# Altium schematic sheet CELLULAR - nRF9160.SchDoc
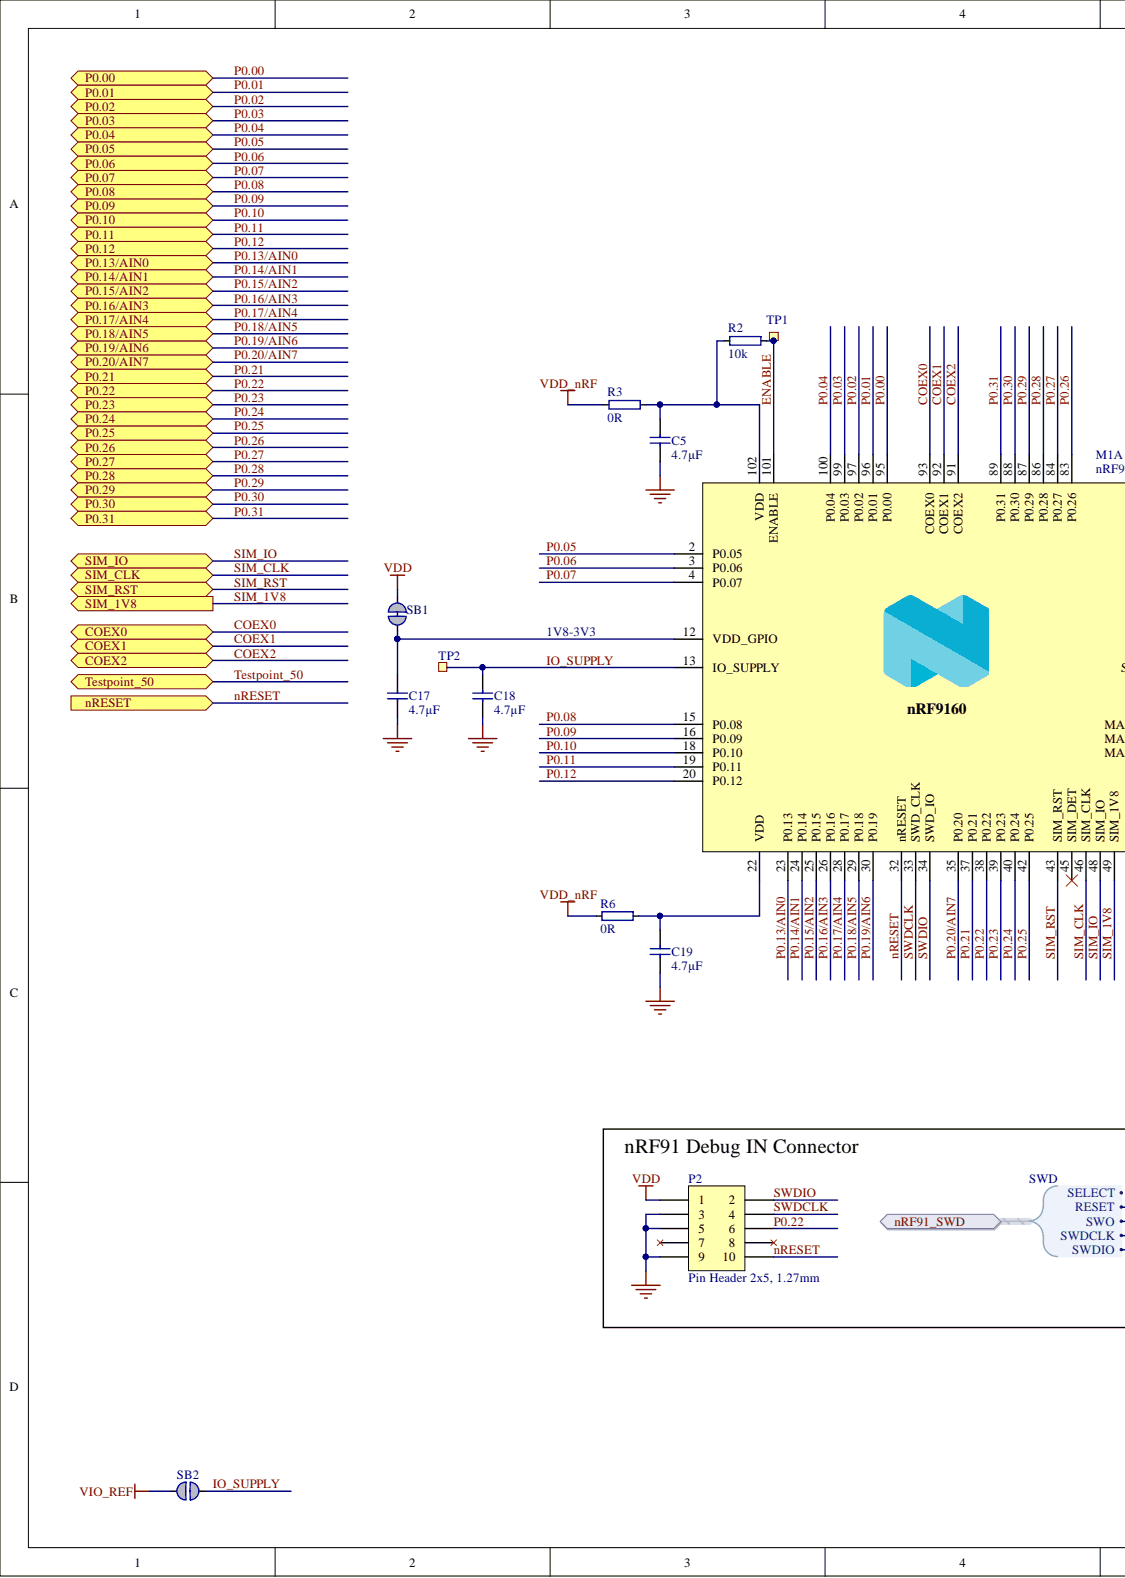
[diagram p0: CELLULAR - nRF9160.SchDoc, left]
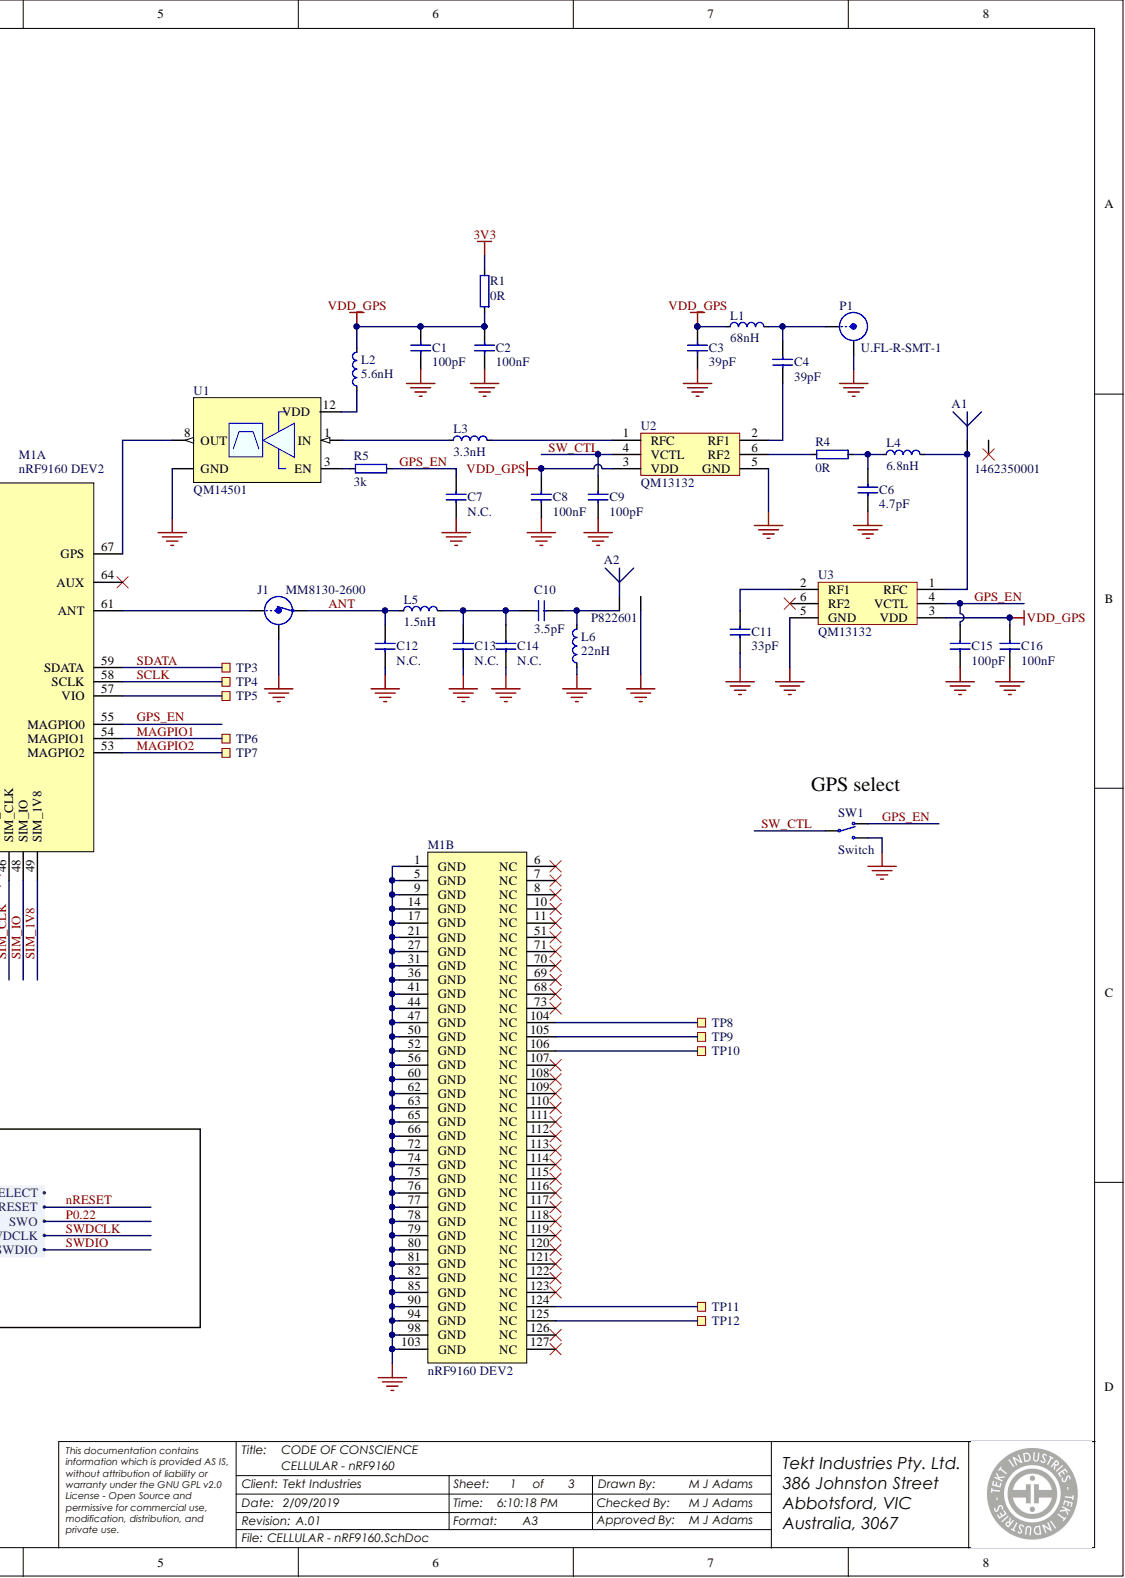
[diagram p1: CELLULAR - nRF9160.SchDoc, right]

## Parts
A1  1462350001  [1462350001]
A2  P822601  [P822601]
C1  100pF  [CAPC0603X03L_C]
C2  100nF  [CAPC0603X03L_C]
C3  39pF  [CAPC0603X03L_C]
C4  39pF  [CAPC0603X03L_C]
C5  4.7µF  [CAPC1005X04L]
C6  4.7pF  [CAPC0603X03L_C]
C7  N.C.  [CAPC0603X03L_C]
C8  100nF  [CAPC0603X03L_C]
C9  100pF  [CAPC0603X03L_C]
C10  3.5pF  [CAPC0603X03L_C]
C11  33pF  [CAPC0603X03L_C]
C12  N.C.  [CAPC0603X03L_C]
C13  N.C.  [CAPC0603X03L_C]
C14  N.C.  [CAPC0603X03L_C]
C15  100pF  [CAPC0603X03L_C]
C16  100nF  [CAPC0603X03L_C]
C17  4.7µF  [CAPC1005X04L]
C18  4.7µF  [CAPC1005X04L]
C19  4.7µF  [CAPC1005X04L]
J1  MM8130-2600  [COAXIAL-SWF]
L1  68nH  [INDC1005X04L]
L2  5.6nH  [INDC0603X03L_C]
L3  3.3nH  [INDC0603X03L_C]
L4  6.8nH  [INDC0603X03L_C]
L5  1.5nH  [INDC0603X03L_C]
L6  22nH  [INDC0603X03L_C]
M1  nRF9160 DEV2  [nRF9160_DEV2_MODULE]  (parts A,B)
P1  U.FL-R-SMT-1  [COAXIAL RF U.FL-R-SMT-1]
P2  Pin Header 2x5, 1.27mm  [HDR_2x5-SMD-1.27mm-K]
R1  0R  [RESC1005X04L]
R2  10k  [RESC0603X03L_C]
R3  0R  [RESC0603X03L_C]
R4  0R  [RESC0603X03L_C]
R5  3k  [RESC0603X03L_C]
R6  0R  [RESC0603X03L_C]
SB1  Solderbridge  [Solderbridge_Shorted]  (value not drawn)
SB2  Solderbridge  [Solderbridge]  (value not drawn)  (no BOM)
SW1  Switch  [CJS-120xA]
TP1  Ø1.5mm,SMD  [TP_SMD_Ø1.5mm]  (value not drawn)  (no BOM)
TP2  Ø1.0mm,SMD  [TP_SMD_Ø1.0mm]  (value not drawn)  (no BOM)
TP3  Ø1.0mm,SMD  [TP_SMD_Ø1.0mm]  (value not drawn)  (no BOM)
TP4  Ø1.0mm,SMD  [TP_SMD_Ø1.0mm]  (value not drawn)  (no BOM)
TP5  Ø1.0mm,SMD  [TP_SMD_Ø1.0mm]  (value not drawn)  (no BOM)
TP6  Ø1.0mm,SMD  [TP_SMD_Ø1.0mm]  (value not drawn)  (no BOM)
TP7  Ø1.0mm,SMD  [TP_SMD_Ø1.0mm]  (value not drawn)  (no BOM)
TP8  Ø1.0mm,SMD  [TP_SMD_Ø1.0mm]  (value not drawn)  (no BOM)
TP9  Ø1.0mm,SMD  [TP_SMD_Ø1.0mm]  (value not drawn)  (no BOM)
TP10  Ø1.0mm,SMD  [TP_SMD_Ø1.0mm]  (value not drawn)  (no BOM)
TP11  Ø1.0mm,SMD  [TP_SMD_Ø1.0mm]  (value not drawn)  (no BOM)
TP12  Ø1.0mm,SMD  [TP_SMD_Ø1.0mm]  (value not drawn)  (no BOM)
U1  QM14501  [QM14501]
U2  QM13132  [QM13132]
U3  QM13132  [QM13132]

## Ports
COEX0, COEX1, COEX2, nRESET, nRF91_SWD, nRF91_SWD.RESET, nRF91_SWD.SELECT, nRF91_SWD.SWDCLK, nRF91_SWD.SWDIO, nRF91_SWD.SWO, P0.00, P0.01, P0.02, P0.03, P0.04, P0.05, P0.06, P0.07, P0.08, P0.09, P0.10, P0.11, P0.12, P0.13/AIN0, P0.14/AIN1, P0.15/AIN2, P0.16/AIN3, P0.17/AIN4, P0.18/AIN5, P0.19/AIN6, P0.20/AIN7, P0.21, P0.22, P0.23, P0.24, P0.25, P0.26, P0.27, P0.28, P0.29, P0.30, P0.31, SIM_1V8, SIM_CLK, SIM_IO, SIM_RST, Testpoint_50

## Nets
3V3: R1.1
GND: A2.2 C1.1 C2.1 C3.2 C5.2 C6.2 C7.1 C8.2 C9.2 C11.2 C12.1 C13.1 C14.1 C15.2 C16.2 C17.2 C18.2 C19.1 J1.2 L6.1 M1.1(GND) M1.5(GND) M1.9(GND) M1.14(GND) M1.17(GND) M1.21(GND) M1.27(GND) M1.31(GND) M1.36(GND) M1.41(GND) M1.44(GND) M1.47(GND) M1.50(GND) M1.52(GND) M1.56(GND) M1.60(GND) M1.62(GND) M1.63(GND) M1.65(GND) M1.66(GND) M1.72(GND) M1.74(GND) M1.75(GND) M1.76(GND) M1.77(GND) M1.78(GND) M1.79(GND) M1.80(GND) M1.81(GND) M1.82(GND) M1.85(GND) M1.90(GND) M1.94(GND) M1.98(GND) M1.103(GND) P1.2 P2.3 P2.5 P2.9 SW1.3 U1.2(GND) U1.4 U1.5 U1.6 U1.7 U1.9 U1.10 U1.11 U2.5(GND) U3.5(GND)
VDD: P2.1 SB1.1
VDD_GPS: C1.2 C2.2 C3.1 C8.1 C16.1 L1.1 L2.2 R1.2 U2.3(VDD) U3.3(VDD)
VDD_nRF: R3.1 R6.1
VIO_REF: SB2.2
ANT: C12.2 J1.3 L5.1
COEX0: M1.93(COEX0) ; port COEX0
COEX1: M1.92(COEX1) ; port COEX1
COEX2: M1.91(COEX2) ; port COEX2
ENABLE: M1.101(ENABLE) R2.2 TP1.1
GPS_EN: C7.2 C15.1 M1.55(MAGPIO0) R5.2 SW1.1 U3.4(VCTL)
IO_SUPPLY: C18.1 M1.13(IO_SUPPLY) SB2.1 TP2.1
MAGPIO1: M1.54(MAGPIO1) TP6.1
MAGPIO2: M1.53(MAGPIO2) TP7.1
nRESET: M1.32(nRESET) P2.10 ; port nRESET, port nRF91_SWD
P0.00: M1.95(P0.00) ; port P0.00
P0.01: M1.96(P0.01) ; port P0.01
P0.02: M1.97(P0.02) ; port P0.02
P0.03: M1.99(P0.03) ; port P0.03
P0.04: M1.100(P0.04) ; port P0.04
P0.05: M1.2(P0.05) ; port P0.05
P0.06: M1.3(P0.06) ; port P0.06
P0.07: M1.4(P0.07) ; port P0.07
P0.08: M1.15(P0.08) ; port P0.08
P0.09: M1.16(P0.09) ; port P0.09
P0.10: M1.18(P0.10) ; port P0.10
P0.11: M1.19(P0.11) ; port P0.11
P0.12: M1.20(P0.12) ; port P0.12
P0.13/AIN0: M1.23(P0.13) ; port P0.13/AIN0
P0.14/AIN1: M1.24(P0.14) ; port P0.14/AIN1
P0.15/AIN2: M1.25(P0.15) ; port P0.15/AIN2
P0.16/AIN3: M1.26(P0.16) ; port P0.16/AIN3
P0.17/AIN4: M1.28(P0.17) ; port P0.17/AIN4
P0.18/AIN5: M1.29(P0.18) ; port P0.18/AIN5
P0.19/AIN6: M1.30(P0.19) ; port P0.19/AIN6
P0.20/AIN7: M1.35(P0.20) ; port P0.20/AIN7
P0.21: M1.37(P0.21) ; port P0.21
P0.22: M1.38(P0.22) P2.6 ; port P0.22, port nRF91_SWD
P0.23: M1.39(P0.23) ; port P0.23
P0.24: M1.40(P0.24) ; port P0.24
P0.25: M1.42(P0.25) ; port P0.25
P0.26: M1.83(P0.26) ; port P0.26
P0.27: M1.84(P0.27) ; port P0.27
P0.28: M1.86(P0.28) ; port P0.28
P0.29: M1.87(P0.29) ; port P0.29
P0.30: M1.88(P0.30) ; port P0.30
P0.31: M1.89(P0.31) ; port P0.31
SCLK: M1.58(SCLK) TP4.1
SDATA: M1.59(SDATA) TP3.1
SIM_1V8: M1.49(SIM_1V8) ; port SIM_1V8
SIM_CLK: M1.46(SIM_CLK) ; port SIM_CLK
SIM_IO: M1.48(SIM_IO) ; port SIM_IO
SIM_RST: M1.43(SIM_RST) ; port SIM_RST
SW_CTL: C9.1 SW1.2 U2.4(VCTL)
SWDCLK: M1.33(SWD_CLK) P2.4 ; port nRF91_SWD
SWDIO: M1.34(SWD_IO) P2.2 ; port nRF91_SWD
NetA1_1: A1.1 L4.2 U3.1(RFC)
NetA2_1: A2.1 C10.2 L6.2
NetC4_1: C4.1 U2.2(RF1)
NetC4_2: C4.2 L1.2 P1.1
NetC5_1: C5.1 M1.102(VDD) R2.1 R3.2
NetC6_1: C6.1 L4.1 R4.2
NetC10_1: C10.1 C13.2 C14.2 L5.2
NetC11_1: C11.1 U3.2(RF1)
NetC17_1: C17.1 M1.12(VDD_GPIO) SB1.2
NetC19_2: C19.2 M1.22(VDD) R6.2
NetJ1_1: J1.1 M1.61(ANT)
NetL2_1: L2.1 U1.12(VDD)
NetL3_1: L3.1 U1.1(IN)
NetL3_2: L3.2 U2.1(RFC)
NetM1_57: M1.57(VIO) TP5.1
NetM1_67: M1.67(GPS) U1.8(OUT)
NetM1_104: M1.104(NC) TP8.1
NetM1_105: M1.105(NC) TP9.1
NetM1_106: M1.106(NC) TP10.1
NetM1_124: M1.124(NC) TP11.1
NetM1_125: M1.125(NC) TP12.1
NetR4_1: R4.1 U2.6(RF2)
NetR5_1: R5.1 U1.3(EN)
N00012: ; port nRF91_SWD
Testpoint_50: ; port Testpoint_50

Not connected: A1 2; M1 6(NC) 7(NC) 8(NC) 10(NC) 11(NC) 45(SIM_DET) 51(NC) 64(AUX) 68(NC) 69(NC) 70(NC) 71(NC) 73(NC) 107(NC) 108(NC) 109(NC) 110(NC) 111(NC) 112(NC) 113(NC) 114(NC) 115(NC) 116(NC) 117(NC) 118(NC) 119(NC) 120(NC) 121(NC) 122(NC) 123(NC) 126(NC) 127(NC); P2 7 8; U3 6(RF2)

## Library data of the parts
A1 = 1462350001_GPS: ANTENNA MOLDED 1.575GHZ GPS; Manufacturer: Molex; Artikkelnr Noca: N.A.; Assembly: Mounted; Database Table Name: Antennas$; Component Kind: Standard; ComponentLink1Description: Datasheet; ComponentLink2Description: Supplier link; Footprint Doc: 1462350001; HelpURL: https://www.molex.com/pdm_docs/sd/1462350001_sd.pdf; LatestRevisionDate: 2018-04-30; Library Name: Nordic Passive DB.DbLib; Manufacturer Part No.: 1462350001; Part Number: 1462350001_GPS; Part number IPS: N.A.; Pin Count: 3; Published: 2018-04-30; Supplier: Digi-Key; Supplier 2: 538-146235-0001; Supplier Part No.: WM15009CT-ND; Value: 1462350001; Publisher: Nordic Semiconductor
A2 = P822601: ANTENNA PRESTTA OCTABAND LTE; Manufacturer: Ethertronics; Artikkelnr Noca: N.A.; Database Table Name: Antennas$; Component Kind: Standard; ComponentLink1Description: Datasheet; ComponentLink2Description: Supplier link; Footprint Doc: P822601; HelpURL: http://www.ethertronics.com/wp-content/uploads/2016/11/Prestta-P822601-P822602-Standard-Cellular-Octa-Band-20160904.pdf; LatestRevisionDate: 2017-07-28; Library Name: Nordic Passive DB.DbLib; Manufacturer Part No.: P822601; Part Number: P822601; Part number IPS: N.A.; Pin Count: 2; Published: 2017-07-28; Supplier: Digi-Key; Supplier 2: Digikey; Supplier Part No.: 939-1057-1-ND; Supplier Part Number 2: 939-1057-1-ND; Value: P822601; Publisher: Nordic Semiconductor
C1 C9 C15 = C0201-100p: Capacitor, NP0, ±2%; Manufacturer: Murata Electronics North America; Manufacturer Part No.: GRM0335C1E101JA01D; Part number IPS: 00000007853; Artikkelnr Noca: N.A.; Database Table Name: Capacitors$; Note: 25V; Component Kind: Standard; ComponentLink1Description: Datasheet; ComponentLink2Description: Supplier link; Footprint Doc: 0201; LatestRevisionDate: 2017-11-09; Library Name: Nordic Passive DB.DbLib; Part Number: C0201-100p; Pin Count: 2; Published: 2014-11-13; Supplier: Digi-Key; Supplier Part No.: 490-3160-1-ND; Value: 100pF; Publisher: Nordic Semiconductor
C2 C8 C16 = C0201-100n: Capacitor, X5R, ±10%; Manufacturer: Murata Electronics North America; Manufacturer Part No.: GRM033R61C104KE84D; Part number IPS: 00000001248; Artikkelnr Noca: N.A.; Database Table Name: Capacitors$; Note: 16V; Component Kind: Standard; ComponentLink1Description: Datasheet; ComponentLink2Description: Supplier link; Footprint Doc: 0201; LatestRevisionDate: 2017-11-09; Library Name: Nordic Passive DB.DbLib; Part Number: C0201-100n; Pin Count: 2; Published: 2014-11-13; Supplier: Digi-Key; Supplier Part No.: 490-9985-1-ND; Value: 100nF; Publisher: Nordic Semiconductor
C3 C4 = C0201-39p: Chip Cap 0201; Manufacturer: Murata Electronics North America; Manufacturer Part No.: GRM0335C1H390JA01D; Artikkelnr Noca: N.A.; Database Table Name: Capacitors$; Note: Chip Cap 1%; Component Kind: Standard; ComponentLink1Description: Datasheet; ComponentLink2Description: Supplier link; Footprint Doc: 0201; LatestRevisionDate: 2014-11-13; Library Name: Nordic Passive DB.DbLib; Part Number: C0201-39p; Part number IPS: N.A.; Pin Count: 2; Published: 2014-11-13; Supplier: Digi-Key; Supplier Part No.: 490-11326-1-ND; Value: 39pF; Publisher: Nordic Semiconductor
C5 C17-C19 = C0402-4µ7: Capacitor, X5R, ±20%; Manufacturer: Murata Electronics North America; Manufacturer Part No.: ZRB15XR61A475KE01D; Part number IPS: 00000001251; Artikkelnr Noca: 20039482; Database Table Name: Capacitors$; Note: 10V; Component Kind: Standard; ComponentLink1Description: Datasheet; ComponentLink2Description: Supplier link; Footprint Doc: 0402; LatestRevisionDate: 2017-11-09; Library Name: Nordic Passive DB.DbLib; Part Number: C0402-4µ7; Pin Count: 2; Published: 2009-05-08; Supplier: Digi-Key; Supplier Part No.: 490-13252-1-ND; Value: 4.7µF; Publisher: Nordic Semiconductor
C6 = C0201-4.7p: Chip Cap 0201; Manufacturer: Murata Electronics North America; Manufacturer Part No.: GRM0335C1H4R7CA01D; Artikkelnr Noca: N.A.; Database Table Name: Capacitors$; Note: Chip Cap 1%; Component Kind: Standard; ComponentLink1Description: Datasheet; ComponentLink2Description: Supplier link; Footprint Doc: 0201; LatestRevisionDate: 2014-11-13; Library Name: Nordic Passive DB.DbLib; Part Number: C0201-4.7p; Part number IPS: N.A.; Pin Count: 2; Published: 2014-11-13; Supplier: Digi-Key; Supplier Part No.: 490-6130-1-ND; Value: 4.7pF; Publisher: Nordic Semiconductor
C7 C12-C14 = C0201-N.C.: Not mounted; Artikkelnr Noca: N.A.; Assembly: Not mounted; Database Table Name: Capacitors$; Note: Chip Cap; Component Kind: Standard; ComponentLink1Description: Datasheet; ComponentLink2Description: Supplier link; Footprint Doc: 0201; LatestRevisionDate: 2014-11-13; Library Name: Nordic Passive DB.DbLib; Part Number: C0201-N.C.; Part number IPS: N.A.; Pin Count: 2; Published: 2014-11-13; Value: N.C.; Publisher: Nordic Semiconductor
C10 = C0201-3.3p: Chip Cap 0201; Manufacturer: Taiyo Yuden; Manufacturer Part No.: UMK063CG3R5CTHF; Artikkelnr Noca: N.A.; Database Table Name: Capacitors$; Note: Chip Cap 1%; Component Kind: Standard; ComponentLink1Description: Datasheet; ComponentLink2Description: Supplier link; Footprint Doc: 0201; LatestRevisionDate: 2014-11-13; Library Name: Nordic Passive DB.DbLib; Part Number: C0201-3.3p; Part number IPS: N.A.; Pin Count: 2; Published: 2014-11-13; Supplier: Digi-Key; Supplier Part No.: 587-5326-1-ND; Value: 3.5pF; Publisher: Nordic Semiconductor
C11 = C0201-33p: Chip Cap 0201; Manufacturer: Murata Electronics North America; Manufacturer Part No.: GRM0335C1H330JA01D; Artikkelnr Noca: N.A.; Database Table Name: Capacitors$; Note: Chip Cap 1%; Component Kind: Standard; ComponentLink1Description: Datasheet; ComponentLink2Description: Supplier link; Footprint Doc: 0201; LatestRevisionDate: 2014-11-13; Library Name: Nordic Passive DB.DbLib; Part Number: C0201-33p; Part number IPS: N.A.; Pin Count: 2; Published: 2014-11-13; Supplier: Digi-Key; Supplier Part No.: 490-6126-1-ND; Value: 33pF; Publisher: Nordic Semiconductor
J1 = MM8130-2600: Coaxial Connector with Switch; Manufacturer: Murata; Part number IPS: N.A.; Artikkelnr Noca: 55029011; Database Table Name: Connectors$; Component Kind: Standard; ComponentLink1Description: Datasheet; ComponentLink2Description: Supplier link; Footprint Doc: COAXIAL-SWF; HelpURL: http://www.murata.com/products/catalog/pdf/o33e.pdf; LatestRevisionDate: 2014-04-24; Library Name: Nordic Passive DB.DbLib; Manufacturer Part No.: MM8130-2600; Part Number: MM8130-2600; Pin Count: 3; Published: 2014-04-24; Supplier: Digi-Key; Supplier 2: Mouser; Supplier Part No.: 490-4981-1-ND; Supplier Part Number 2: 81-MM8130-2600RA2; Value: MM8130-2600; Publisher: Nordic Semiconductor
L1 = L0402-68n: Chip Inductor; Manufacturer: Murata Electronics North America; Manufacturer Part No.: LQG15HS68NJ02D; Artikkelnr Noca: N.A.; Database Table Name: Inductors$; Component Kind: Standard; ComponentLink1Description: Datasheet; ComponentLink2Description: Supplier link; Footprint Doc: 0402; LatestRevisionDate: 2014-06-12; Library Name: Nordic Passive DB.DbLib; Part Number: L0402-68n; Part number IPS: N.A.; Pin Count: 2; Published: 2014-06-12; Supplier: Digi-Key; Supplier Part No.: 490-2633-1-ND; Value: 68nH; Publisher: Nordic Semiconductor
L2 = L0201-5n6: Chip Inductor; Manufacturer: Murata Electronics North America; Manufacturer Part No.: LQP03TN5N6H02D; Artikkelnr Noca: N.A.; Database Table Name: Inductors$; Note: High frequency chip inductor ±5%; Component Kind: Standard; ComponentLink1Description: Datasheet; ComponentLink2Description: Supplier link; Footprint Doc: 0201; LatestRevisionDate: 2013-04-10; Library Name: Nordic Passive DB.DbLib; Part Number: L0201-5n6; Part number IPS: N.A.; Pin Count: 2; Published: 2013-04-10; Supplier: Digi-Key; Supplier Part No.: 490-6734-1-ND; Value: 5.6nH; Publisher: Nordic Semiconductor
L3 = L0201-3n3: Chip Inductor; Manufacturer: Murata Electronics North America; Manufacturer Part No.: LQP03TN3N3B02D; Artikkelnr Noca: N.A.; Database Table Name: Inductors$; Note: High frequency chip inductor ±5%; Component Kind: Standard; ComponentLink1Description: Datasheet; ComponentLink2Description: Supplier link; Footprint Doc: 0201; LatestRevisionDate: 2013-04-10; Library Name: Nordic Passive DB.DbLib; Part Number: L0201-3n3; Part number IPS: N.A.; Pin Count: 2; Published: 2013-04-10; Supplier: Digi-Key; Supplier Part No.: 490-6728-1-ND; Value: 3.3nH; Publisher: Nordic Semiconductor
L4 = L0201-6n8: Chip Inductor; Manufacturer: Murata Electronics North America; Manufacturer Part No.: LQP03TN6N8J02D; Artikkelnr Noca: N.A.; Database Table Name: Inductors$; Note: High frequency chip inductor ±5%; Component Kind: Standard; ComponentLink1Description: Datasheet; ComponentLink2Description: Supplier link; Footprint Doc: 0201; LatestRevisionDate: 2013-04-10; Library Name: Nordic Passive DB.DbLib; Part Number: L0201-6n8; Part number IPS: N.A.; Pin Count: 2; Published: 2013-04-10; Supplier: Digi-Key; Supplier Part No.: 490-5564-1-ND; Value: 6.8nH; Publisher: Nordic Semiconductor
L5 = L0201-1n5: Chip Inductor; Manufacturer: Murata Electronics North America; Manufacturer Part No.: LQP03TG1N5B02D; Artikkelnr Noca: N.A.; Database Table Name: Inductors$; Note: High frequency chip inductor ±5%; Component Kind: Standard; ComponentLink1Description: Datasheet; ComponentLink2Description: Supplier link; Footprint Doc: 0201; LatestRevisionDate: 2013-04-10; Library Name: Nordic Passive DB.DbLib; Part Number: L0201-1n5; Part number IPS: N.A.; Pin Count: 2; Published: 2013-04-10; Supplier: Digi-Key; Supplier Part No.: 490-14701-1-ND; Value: 1.5nH; Publisher: Nordic Semiconductor
L6 = L0201-22n: Chip Inductor; Manufacturer: Taiyo Yuden; Manufacturer Part No.: HKQ0603W22NH-T; Artikkelnr Noca: N.A.; Database Table Name: Inductors$; Note: High frequency chip inductor ±10%; Component Kind: Standard; ComponentLink1Description: Datasheet; ComponentLink2Description: Supplier link; Footprint Doc: 0201; LatestRevisionDate: 2013-04-10; Library Name: Nordic Passive DB.DbLib; Part Number: L0201-22n; Part number IPS: N.A.; Pin Count: 2; Published: 2013-04-10; Supplier: Digi-Key; Supplier Part No.: 587-4663-1-ND; Value: 22nH; Publisher: Nordic Semiconductor
M1 = nRF9160_DEV2_MODULE_DK: nRF9160 MODULE, SiP LGA; Manufacturer: Nordic Semiconductor ASA; Manufacturer Part No.: NRF9160-SICA; Part number Sanmina: LFNPNSM800002; Database Table Name: Finland$; Delivery: X; Component Kind: Standard; Footprint Doc: 16.0*10.5*1.1; Library Name: Nordic Finland DB.DbLib; Part Number: nRF9160_DEV2_MODULE_DK; Pin Count: 161; Supplier: Digi-Key; Supplier Part No.: 1490-1074-1-ND; Value: nRF9160 DEV2
P1 = U.FL-R-SMT-1: ONN U.FL RCPT STR 50 OHM SMD; Manufacturer: Hirose Electric Co Ltd; Artikkelnr Noca: N.A.; Database Table Name: Connectors$; Component Kind: Standard; ComponentLink1Description: Datasheet; ComponentLink1URL: https://media.digikey.com/pdf/Data%20Sheets/Hirose%20PDFs/UFL%20Series.pdf; ComponentLink2Description: Supplier link; Footprint Doc: COAXIAL RF U.FL-R-SMT-1; LatestRevisionDate: 2018-05-07; Library Name: Nordic Passive DB.DbLib; Manufacturer Part No.: U.FL-R-SMT-1; Part Number: U.FL-R-SMT-1; Part number IPS: N.A.; Pin Count: 3; Published: 2018-05-07; Supplier: Digi-Key; Supplier Part No.: H11891DKR-ND; Value: U.FL-R-SMT-1; Publisher: Nordic Semiconductor
P2 = Pin Header 2x5 SMD, 1.27mm, KS: Pin Header 2x5, 1.27mm (50mil), SMD, Keying Shroud; Manufacturer: Samtec Inc.; Manufacturer Part No.: FTSH-105-01-F-DV-K-TR; Part number IPS: N.A.; Artikkelnr Noca: 55010009; Database Table Name: Connectors$; Component Kind: Standard; ComponentLink1Description: Datasheet; ComponentLink2Description: Supplier link; Footprint Doc: HDR_2x5-SMD-1.27mm; HelpURL: \\hizz\pro\app9717\Datasheet\connectores\W-CON\3132-XXMXXBK00XX.pdf; LatestRevisionDate: 2016-04-21; Library Name: Nordic Passive DB.DbLib; Part Number: Pin Header 2x5 SMD, 1.27mm, KS; Pin Count: 10; Published: 2016-01-22; Supplier: Digi-Key; Supplier 2: Samtec; Supplier Part No.: SAM13160CT-ND; Supplier Part Number 2: FTSH-105-01-F-DV-K; Value: Pin Header 2x5, 1.27mm; Publisher: Nordic Semiconductor
R1 = R0402-0R: Resistor, ±5%, 0.063W; Manufacturer: Stackpole Electronics Inc; Manufacturer Part No.: RMCF0402ZT0R00; Part number IPS: N.A.; Artikkelnr Noca: 27049000; Database Table Name: Resistors$; Note: Chip Res 5%; Component Kind: Standard; ComponentLink1Description: Datasheet; ComponentLink2Description: Supplier link; Footprint Doc: 0402; LatestRevisionDate: 2009-11-23; Library Name: Nordic Passive DB.DbLib; Part Number: R0402-0R; Pin Count: 2; Published: 2009-05-08; Supplier: Digi-Key; Supplier Part No.: RMCF0402ZT0R00CT-ND; Value: 0R; Publisher: Nordic Semiconductor
R2 = R0201-10k: Resistor, ±1%, 0.05W; Manufacturer: Samsung Electro-Mechanics; Manufacturer Part No.: RC0603F103CS; Part number IPS: 00000001255; Artikkelnr Noca: N.A.; Database Table Name: Resistors$; Note: Chip Res 1%; Component Kind: Standard; ComponentLink1Description: Datasheet; ComponentLink2Description: Supplier link; Footprint Doc: 0201; LatestRevisionDate: 2014-11-13; Library Name: Nordic Passive DB.DbLib; Part Number: R0201-10k; Pin Count: 2; Published: 2014-11-13; Supplier: Digi-Key; Supplier Part No.: 1276-3670-1-ND; Value: 10k; Publisher: Nordic Semiconductor
R3 R4 R6 = R0201-0R: Resistor, ±5%, 0.05W; Manufacturer: Yageo; Manufacturer Part No.: RC0201JR-070RL; Part number IPS: 00000003245; Artikkelnr Noca: N.A.; Database Table Name: Resistors$; Note: Chip Res 5%; Component Kind: Standard; ComponentLink1Description: Datasheet; ComponentLink2Description: Supplier link; Footprint Doc: 0201; LatestRevisionDate: 2017-11-09; Library Name: Nordic Passive DB.DbLib; Part Number: R0201-0R; Pin Count: 2; Published: 2014-11-13; Supplier: Digi-Key; Supplier Part No.: 311-0.0NCT-ND; Value: 0R; Publisher: Nordic Semiconductor
R5 = R0201-3k: Resistor, ±1%, 0.05W; Manufacturer: Yageo; Manufacturer Part No.: RC0201FR-073KL; Artikkelnr Noca: N.A.; Database Table Name: Resistors$; Note: Chip Res 1%; Component Kind: Standard; ComponentLink1Description: Datasheet; ComponentLink2Description: Supplier link; Footprint Doc: 0201; LatestRevisionDate: 2014-11-13; Library Name: Nordic Passive DB.DbLib; Part Number: R0201-3k; Part number IPS: N.A.; Pin Count: 2; Published: 2014-11-13; Supplier: Digi-Key; Supplier Part No.: YAG2656CT-ND; Value: 3k; Publisher: Nordic Semiconductor
SB1 = Solderbridge Shorted: Shorted Solderbridge; Artikkelnr Noca: N.A.; Database Table Name: Connectors$; Component Kind: Net Tie; ComponentLink1Description: Datasheet; ComponentLink2Description: Supplier link; Footprint Doc: Solderbridge_Shorted; LatestRevisionDate: 2012-08-07; Library Name: Nordic Passive DB.DbLib; Part Number: Solderbridge Shorted; Part number IPS: N.A.; Pin Count: 2; Published: 2012-08-07; Value: Solderbridge; Publisher: Nordic Semiconductor
SB2 = Solderbridge: Artikkelnr Noca: N.A.; Database Table Name: Connectors$; ComponentLink1Description: Datasheet; ComponentLink2Description: Supplier link; Description: Solderbridge; Footprint Doc: Solderbridge; LatestRevisionDate: 2009-12-14; Library Name: Nordic Passive DB.DbLib; Part Number: Solderbridge; Part number IPS: N.A.; Pin Count: 2; Published: 2009-12-14; Value: Solderbridge; Publisher: Nordic Semiconductor
SW1 = CJS-1200TA: SMD slide Switch (ON-ON); Manufacturer: Copal Electronics Inc; Part number IPS: N.A.; Artikkelnr Noca: 50019068; Database Table Name: Rele_Switch$; Component Kind: Standard; ComponentLink1Description: Datasheet; ComponentLink2Description: Supplier link; Footprint Doc: CJS-120xA; HelpURL: http://media.digikey.com/pdf/Data%20Sheets/COPAL%20Electronics%20PDFs/CJS.pdf; LatestRevisionDate: 2012-10-03; Library Name: Nordic Discrete DB.DbLib; Manufacturer Part No.: CJS-1200TA; Part Number: CJS-1200TA; Pin Count: 3; Published: 2012-10-03; Supplier: Digi-Key; Supplier Part No.: 563-1021-2-ND; Value: Switch; Publisher: Nordic Semiconductor
TP1 = TP_SMD_Ø1.5MM: 1.0mm circular SMD testpad; Artikkelnr Noca: N.A.; Database Table Name: Connectors$; ComponentLink1Description: Datasheet; ComponentLink2Description: Supplier link; Footprint Doc: TP_SMD_Ø1.5mm; LatestRevisionDate: 2016-06-02; Library Name: Nordic Passive DB.DbLib; Part Number: TP_SMD_Ø1.5MM; Part number IPS: N.A.; Pin Count: 1; Published: 2016-06-02; Value: Ø1.5mm,SMD; Publisher: Nordic Semiconductor
TP2-TP12 = TP_SMD_Ø1.0MM: 1.0mm circular SMD testpad; Artikkelnr Noca: N.A.; Database Table Name: Connectors$; ComponentLink1Description: Datasheet; ComponentLink2Description: Supplier link; Footprint Doc: TP_SMD_Ø1.0mm; LatestRevisionDate: 2013-06-11; Library Name: Nordic Passive DB.DbLib; Part Number: TP_SMD_Ø1.0MM; Part number IPS: N.A.; Pin Count: 1; Published: 2013-06-11; Value: Ø1.0mm,SMD; Publisher: Nordic Semiconductor
U1 = QM14501: GPS, GLONASS, and BeiDou Low Noise Amplifier With Integrated Output SAW Filter, 1.55 to 1.61 GHz, 13.5 dB; Manufacturer: Qorvo; Artikkelnr Noca: N.A.; Database Table Name: Misc IC$; Component Kind: Standard; ComponentLink1Description: Datasheet; ComponentLink1URL: \\hizz\pro\app9717\Datasheet\integated circuits\qorvo\QM14501 Datasheet.pdf; ComponentLink2Description: Supplier link; Footprint Doc: QM14501; HelpURL: https://www.rfmw.com/Products/Detail/QM14501-Qorvo/597159/; LatestRevisionDate: 2018-10-22; Library Name: Nordic Active DB.DbLib; Manufacturer Part No.: QM14501; Part Number: QM14501; Part number IPS: N.A.; Pin Count: 12; Published: 2018-01-16; Supplier: Qorvo; Supplier Part No.: QM14501; Value: QM14501; Publisher: Nordic Semiconductor
U2 U3 = QM13132: Single Pole Double Throw Switch; Manufacturer: Qorvo; Artikkelnr Noca: N.A.; Database Table Name: Misc IC$; Component Kind: Standard; ComponentLink1Description: Datasheet; ComponentLink2Description: Supplier link; LatestRevisionDate: 2018-12-14; Library Name: Nordic Active DB.DbLib; Manufacturer Part No.: QM13132; Part Number: QM13132; Part number IPS: N.A.; Pin Count: 6; Published: 2018-12-14; Supplier: Qorvo; Supplier Part No.: QM13132; Value: QM13132; Publisher: Nordic Semiconductor
